# Altium schematic sheet P04_watchdog.SchDoc
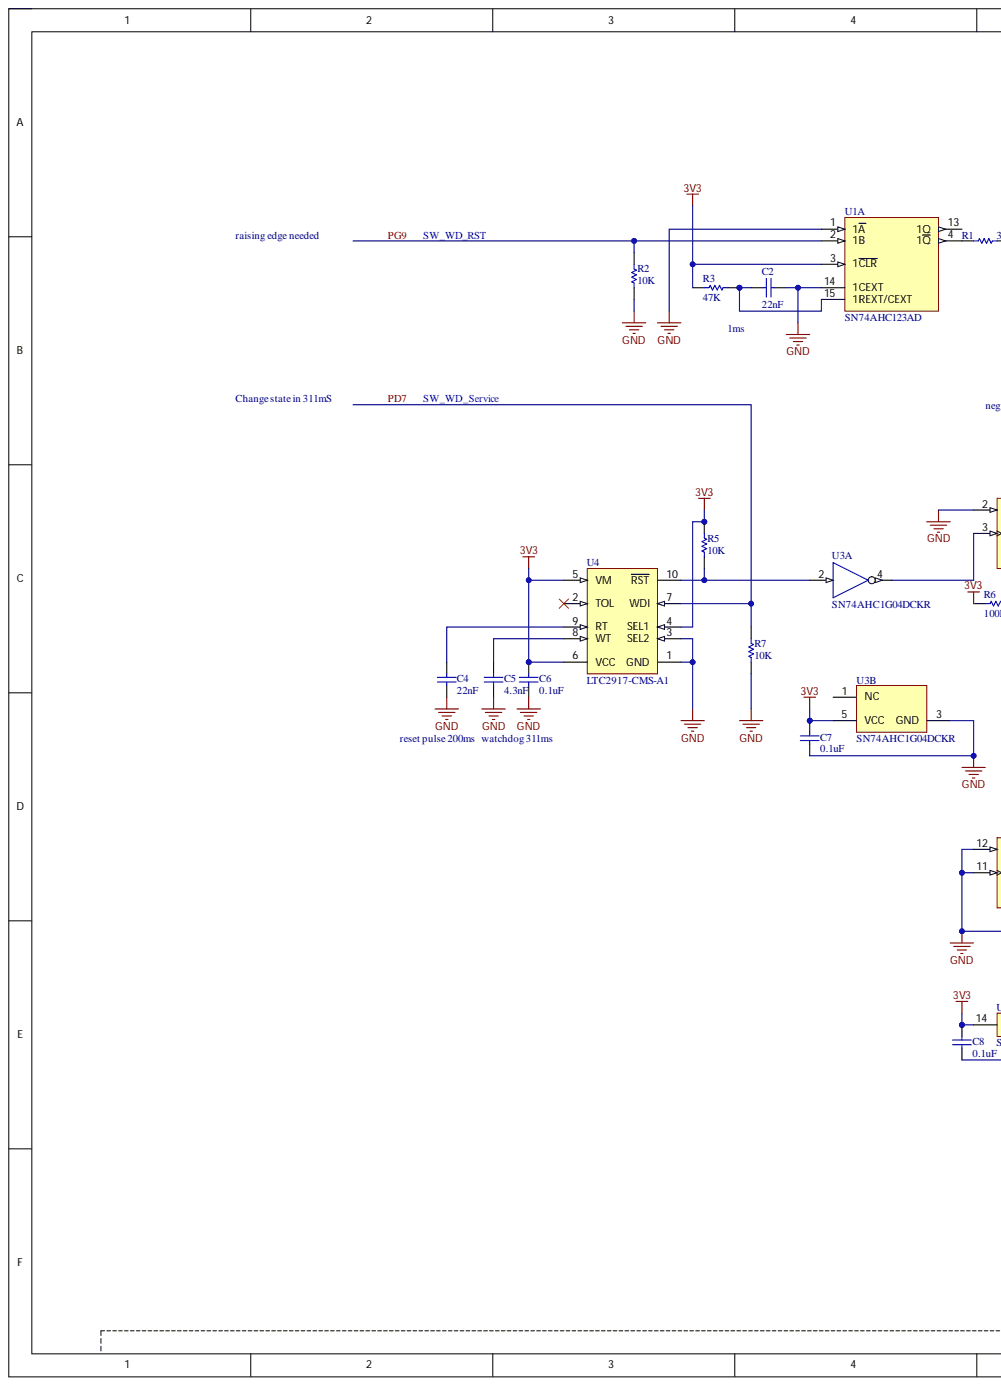
[diagram p0: P04_watchdog.SchDoc, left]
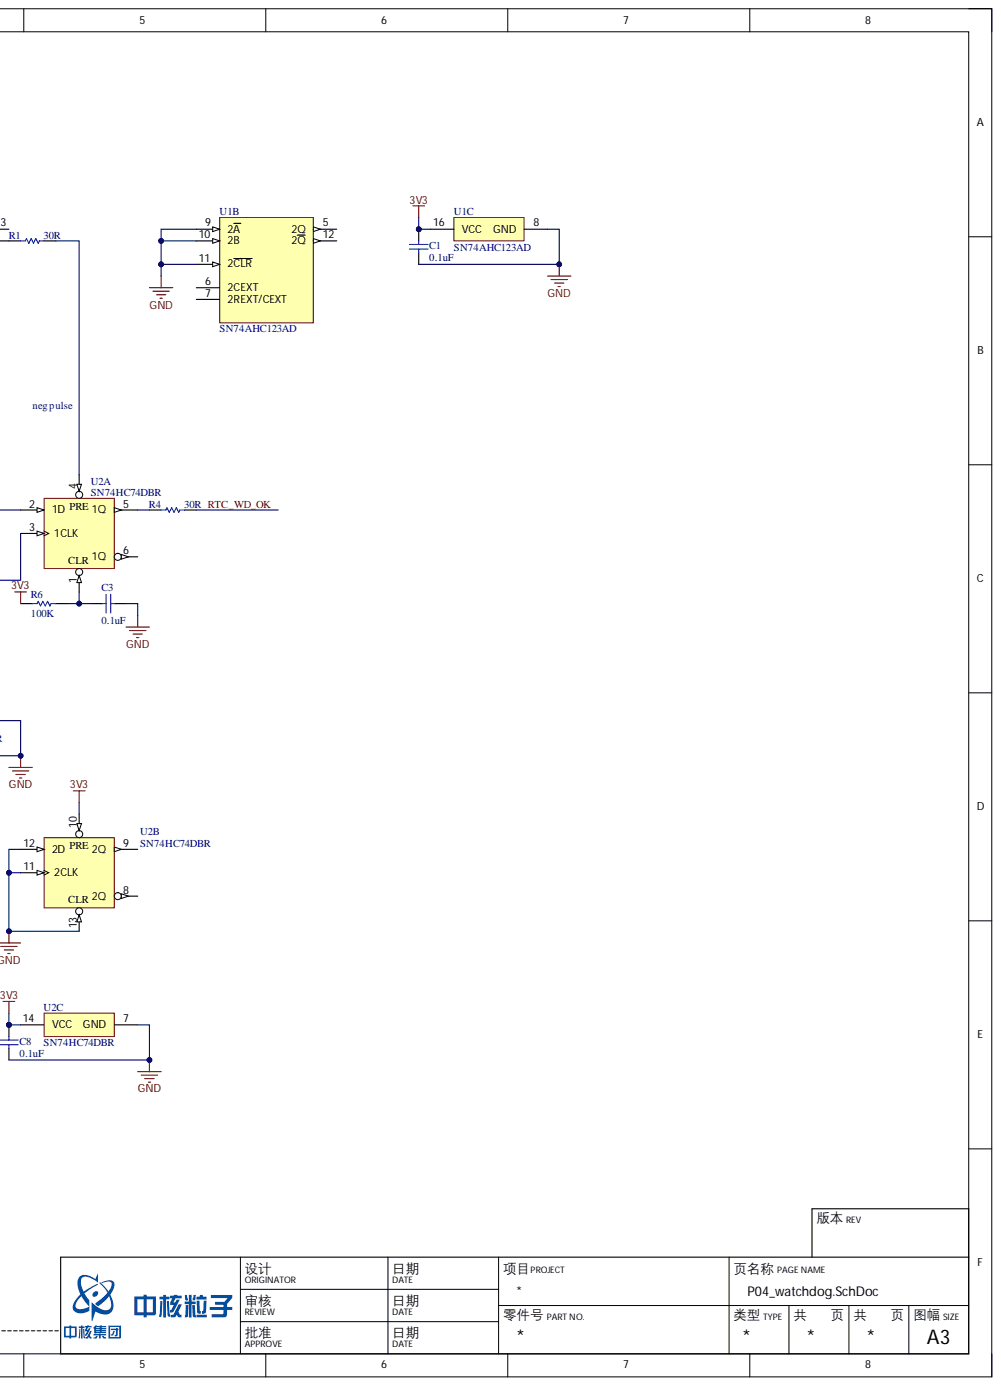
[diagram p1: P04_watchdog.SchDoc, right]

## Parts
C1  0.1uF  [C0603(1608)]
C2  22nF  [C0603(1608)]
C3  0.1uF  [C0603(1608)]
C4  22nF  [C0603(1608)]
C5  4.3nF  [C0603(1608)]
C6  0.1uF  [C0603(1608)]
C7  0.1uF  [C0603(1608)]
C8  0.1uF  [C0603(1608)]
R1  30R  [R0603(1608)]
R2  10K  [R0603(1608)]
R3  47K  [R0603(1608)]
R4  30R  [R0603(1608)]
R5  10K  [R0603(1608)]
R6  100K  [R0603(1608)]
R7  10K  [R0603(1608)]
U1  SN74AHC123AD  [SOIC16_N]  (parts A,B,C)
U2  SN74HC74DBR  [SSOP14_N]  (parts A,B,C)
U3  SN74AHC1G04DCKR  [SC70-5]  (parts A,B)
U4  LTC2917-CMS-A1  [MSOP10_N]

## Nets
3V3: C1.2 C6.2 C7.2 C8.2 R3.2 R5.2 R6.2 U1.3(1CLR) U1.16(VCC) U2.10 U2.14(VCC) U3.5(VCC) U4.4(SEL1) U4.5(VM) U4.6(VCC)
GND: C1.1 C2.1 C3.1 C4.2 C5.1 C6.1 C7.1 C8.1 R2.1 R7.1 U1.1(1A) U1.8(GND) U1.9(2A) U1.10(2B) U1.11(2CLR) U1.14(1CEXT) U2.2(1D) U2.7(GND) U2.11(2CLK) U2.12(2D) U2.13 U3.3(GND) U4.1(GND) U4.3(SEL2)
PD7: R7.2 U4.7(WDI)
PG9: R2.2 U1.2(1B)
RTC_WD_OK: R4.2
NetC2_2: C2.2 R3.1 U1.15(1REXT/CEXT)
NetC3_2: C3.2 R6.1 U2.1
NetC4_1: C4.1 U4.9(RT)
NetC5_2: C5.2 U4.8(WT)
NetR1_1: R1.1 U1.4(1Q)
NetR1_2: R1.2 U2.4
NetR4_1: R4.1 U2.5(1Q)
NetR5_1: R5.1 U3.2 U4.10(RST)
NetU2_3: U2.3(1CLK) U3.4

Not connected: U1 5(2Q) 6(2CEXT) 7(2REXT/CEXT) 12(2Q) 13(1Q); U2 6(1Q) 8(2Q) 9(2Q); U3 1(NC); U4 2(TOL)

## Library data of the parts
C1 C3 C6-C8 = Cap 0.1uF 0603-25V: ceramic 25V; 价格: ¥0.092@100; 制造商: KEMET; 型号: C0603C104K3RACTU; Component Kind: Standard; Library Name: RCL.SchLib; 供应商及库存编号: e络盟 1650835
C2 C4 = Cap 22nF 0603-25V: ceramic 25V; 价格: ¥0.13@100; 制造商: KEMET; 型号: C0603C223K3RACTU; Component Kind: Standard; Library Name: RCL.SchLib; 供应商及库存编号: e络盟 2409040
C5 = Cap 4.3nF 0603-50V: ceramic 50V; 价格: ¥0.91@100; 制造商: KEMET; 型号: C0603C432J5GACTU; Component Kind: Standard; Library Name: RCL.SchLib; 供应商及库存编号: e络盟  1865494
R1 R4 = Res 30R 0603: Res ±1%; Component Kind: Standard; Library Name: RCL.SchLib
R2 R5 R7 = Res 10K 0603: Res ±1%; Component Kind: Standard; Library Name: RCL.SchLib
R3 = Res 47K 0603: Res ±1%; Component Kind: Standard; Library Name: RCL.SchLib
R6 = Res 100K 0603: Res ±1%; Component Kind: Standard; Library Name: RCL.SchLib
U1 = SN74AHC123AD: DUAL RETRIG MULTIVIB 16-SOIC; 价格: ￥1.87@100; 制造商: Texas Instruments; Component Kind: Standard; Library Name: IC.SchLib; 供应商及库存编号: e络盟 1740281; 型号: SN74AHC123AD
U2 = SN74HC74DBR: D-TYPE POS TRG DUAL 14SSOP; 价格: $0.21@100; 制造商: Texas Instruments; Component Kind: Standard; Library Name: IC.SchLib; 供应商及库存编号: digikey 296-8370-1-ND; 型号: SN74HC74DBR
U3 = SN74AHC1G04DCKR: SINGLE INVERTER GATE SC70-5; 价格: $0.09@3K; 制造商: Texas Instruments; Component Kind: Standard; Library Name: IC.SchLib; 供应商及库存编号: digikey 296-1090-2-ND; 型号: SN74AHC1G04DCKR
U4 = LTC2917-CMS-A1: SUPERVISOR W/TIMER 10MSOP; 价格: ￥18.9@25; 制造商: Linear Technology; 型号: LTC2917CMS-A1#PBF; Component Kind: Standard; Library Name: IC.SchLib; 供应商及库存编号: digikey LTC2917CMS-A1#PBF-ND
